# Altium schematic sheet CSMS.SchDoc
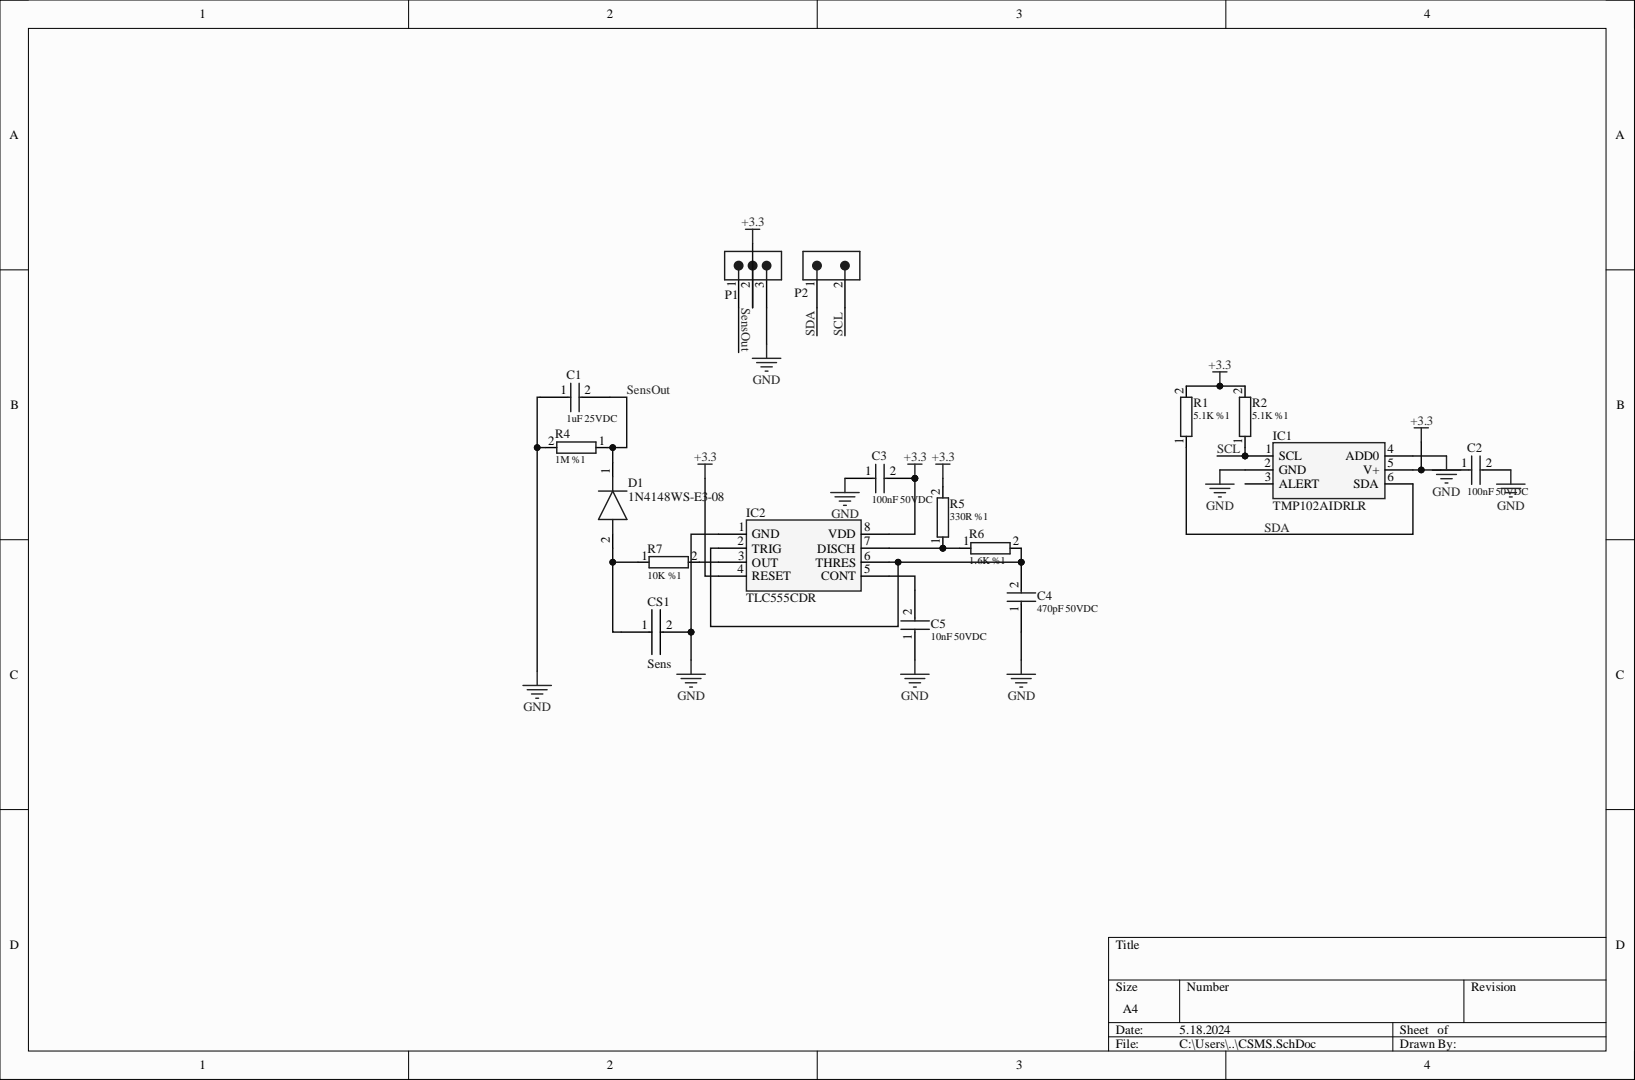
[diagram p0: CSMS.SchDoc]

## Parts
C1  value 1uF 25VDC  [0603 CAP]  (hidden comment: CL10B105KA8NNNC)
C2  value 100nF 50VDC  [0603 CAP]  (hidden comment: CL10B104KB8NNNC)
C3  value 100nF 50VDC  [0603 CAP]  (hidden comment: CL10B104KB8NNNC)
C4  value 470pF 50VDC  [0603 CAP]  (hidden comment: CL10B471KB8NNNC)
C5  value 10nF 50VDC  [0603 CAP]  (hidden comment: CC0603KRX7R9BB103)
CS1  Sens  [Sens]
D1  1N4148WS-E3-08  [SOD2513X110N]
IC1  TMP102AIDRLR  [SOTFL50P160X60-6N]
IC2  TLC555CDR  [SOIC127P600X175-8N]
P1  61000318221  [61000318221]  (value not drawn)
P2  61000218221  [61000218221]  (value not drawn)
R1  value 5.1K %1  [0603 RES]  (hidden comment: 0603WAF5101T5E)
R2  value 5.1K %1  [0603 RES]  (hidden comment: 0603WAF5101T5E)
R4  value 1M %1  [0603 RES]  (hidden comment: 0603SAF1004T5E)
R5  value 330R %1  [0603 RES]  (hidden comment: 0603SAF3300T5E)
R6  value 1.6K %1  [0603 RES]  (hidden comment: 0603SAF1601T5E)
R7  value 10K %1  [0603 RES]  (hidden comment: 0603SAF1002T5E)

## Nets
+3.3: C2.1 C3.2 IC1.5(V+) IC2.4(RESET) IC2.8(VDD) P1.2 R1.2 R2.2 R5.2
GND: C1.1 C2.2 C3.1 C4.1 C5.1 CS1.2 IC1.2(GND) IC1.4(ADD0) IC2.1(GND) P1.3 R4.2
SCL: IC1.1(SCL) P2.2 R2.1
SDA: IC1.6(SDA) P2.1 R1.1
SensOut: C1.2 D1.1 P1.1 R4.1
NetC4_2: C4.2 IC2.2(TRIG) IC2.6(THRES) R6.2
NetC5_2: C5.2 IC2.5(CONT)
NetCS1_1: CS1.1 D1.2 R7.1
NetR5_1: IC2.7(DISCH) R5.1 R6.1
NetR7_2: IC2.3(OUT) R7.2

Not connected: IC1 3(ALERT)

## Library data of the parts
C1 = CL10B105KA8NNNC: 1uF 25VDC ±10% X7R MLCC 0603; Component Kind: Standard; Ozdisan Price/Stock: https://ozdisan.com/Product/Detail/522144/CL10A106MO8NQNC; Supplier 1: Ozdisan; Supplier 2: Digi-Key; Supplier Part Number 1: CL10B105KA8NNNC; Supplier Part Number 2: 1276-1184-1-ND; Library Name: CSMS.SchLib
C2 C3 = CL10B104KB8NNNC: 100nF 50VDC ±10% X7R MLCC 0603; Component Kind: Standard; Ozdisan Price/Stock: https://ozdisan.com/Product/Detail/522144/CL10A106MO8NQNC; Supplier 1: Digi-Key; Supplier Part Number 1: 1276-1000-1-ND; Library Name: CSMS.SchLib
C4 = CL10B471KB8NNNC: 470pF 50VDC ±10% X7R MLCC 0603; Component Kind: Standard; Ozdisan Price/Stock: https://ozdisan.com/Product/Detail/522144/CL10A106MO8NQNC; Supplier 1: Mouser; Supplier Part Number 1: 187-CL10B471KB8NNNC; Library Name: CSMS.SchLib
C5 = CC0603KRX7R9BB103: 10nF, 50 V, ± 10%, X7R, 0603, Multilayer Ceramic Capacitor,; Component Kind: Standard; Ozdisan Price/Stock: https://ozdisan.com/Product/Detail/522144/CL10A106MO8NQNC; Supplier 1: Mouser; Supplier Part Number 1: 603-CC603KRX7R9BB103; Library Name: CSMS.SchLib
CS1 = Sens: Pcb Sens; Component Kind: Standard; Library Name: CSMS.SchLib
D1 = 1N4148WS-E3-08: Diode; Manufacturer_Name: Vishay; Arrow Part Number: 1N4148WS-E3-08; Arrow Price/Stock: https://www.arrow.com/en/products/1n4148ws-e3-08/vishay?region=europe; Component Kind: Standard; Datasheet Link: https://datasheet.datasheetarchive.com/originals/distributors/Datasheets-DGA23/1390442.pdf; Height: 1.15mm; Manufacturer_Part_Number: 1N4148WS-E3-08; Mouser Part Number: 78-1N4148WS-E3-08; Mouser Price/Stock: https://www.mouser.co.uk/ProductDetail/Vishay-Semiconductors/1N4148WS-E3-08?qs=bTzheeSci6R8fv1OBRENhQ%3D%3D; Supplier 1: Mouser; Supplier Part Number 1: 78-1N4148WS-E3-08; Library Name: CSMS.SchLib
IC1 = TMP102AIDRLR: Integrated Circuit; Manufacturer_Name: Texas Instruments; Arrow Part Number: TMP102AIDRLR; Arrow Price/Stock: https://www.arrow.com/en/products/tmp102aidrlr/texas-instruments?region=nac; Component Kind: Standard; Datasheet Link: http://www.ti.com/lit/gpn/tmp102; Height: 0.6mm; Manufacturer_Part_Number: TMP102AIDRLR; Mouser Part Number: 595-TMP102AIDRLR; Mouser Price/Stock: https://www.mouser.co.uk/ProductDetail/Texas-Instruments/TMP102AIDRLR?qs=SUwrw6jWmlKK8eMrKfK4LA%3D%3D; Library Name: CSMS.SchLib
IC2 = TLC555CDR: 555 Astable 2.1-MHz, 250-µA, Low-Power Timer 8-SOIC 0 to 70; Manufacturer_Name: Texas Instruments; Arrow Part Number: TLC555CDR; Arrow Price/Stock: https://www.arrow.com/en/products/tlc555cdr/texas-instruments?region=nac; Component Kind: Standard; Datasheet Link: https://www.ti.com/lit/ds/symlink/tlc555.pdf?ts=1599218356509&ref_url=https%253A%252F%252Fwww.ti.com%252Fstore%252Fti%252Fen%252Fp%252Fproduct%252F%253Fp%253DTLC555CDR%2526keyMatch%253DTLC555CDR%2526tisearch%253DSearch-EN-everything%2526usecase%253DOPN; Height: 1.75mm; Manufacturer_Part_Number: TLC555CDR; Mouser Part Number: 595-TLC555CDR; Mouser Price/Stock: https://www.mouser.co.uk/ProductDetail/Texas-Instruments/TLC555CDR?qs=gb35HGp1gQImgWgRW%252BGYaw%3D%3D; Supplier 1: Mouser; Supplier Part Number 1: 595-TLC555CDR; Library Name: CSMS.SchLib
P1 = 61000318221: WR-PHD Pin Header, THT, Angled, pitch 2.54mm, 1 Row, 3P; Manufacturer: Wurth Elektronik; Manufacturer Part Number: 61300311021; Contact Resistance: 20mΩ; Rated Current: 3A; Withstanding Voltage: 500V; Working Voltage: 250V; Category: Board-to-Board Connectors; Component Kind: Standard; ComponentLink1Description: Website Link; ComponentLink1URL: https://www.we-online.com/redexpert/article/61300311021?ad; ComponentLink2Description: Datasheet Link; ComponentLink2URL: https://www.we-online.com/redexpert/spec/61300311021?ad; Gender: Pin Header; Match Code: WR-PHD; Mount: Through Hole; Number of Pins: 3; Number of Rows: 1; Operating Temperature Max: 105°C; Operating Temperature Min: -40°C; Orientation: Angled; Packaging: Bag; Pitch: 2.54mm; Supplier 1: Digi-Key; Supplier Part Number 1: 732-5336-ND; Library Name: CSMS.SchLib
P2 = 61000218221: WR-PHD Pin Header, THT, Angled, pitch 2.54mm, 1 Row, 3P; Manufacturer: Wurth Elektronik; Manufacturer Part Number: 61300311021; Contact Resistance: 20mΩ; Rated Current: 3A; Withstanding Voltage: 500V; Working Voltage: 250V; Category: Board-to-Board Connectors; Component Kind: Standard; ComponentLink1Description: Website Link; ComponentLink1URL: https://www.we-online.com/redexpert/article/61300311021?ad; ComponentLink2Description: Datasheet Link; ComponentLink2URL: https://www.we-online.com/redexpert/spec/61300311021?ad; Gender: Pin Header; Match Code: WR-PHD; Mount: Through Hole; Number of Pins: 3; Number of Rows: 1; Operating Temperature Max: 105°C; Operating Temperature Min: -40°C; Orientation: Angled; Packaging: Bag; Pitch: 2.54mm; Supplier 1: Digi-Key; Supplier Part Number 1: 732-5336-ND; Library Name: CSMS.SchLib
R1 = 0603WAF5101T5E: 5.1 K Ohms 1% 1/10W-S 100PPM RES 0603; Value: 5.1K %1; Component Kind: Standard; JLCPCB Part #: C23186; Ozdisan Price/Stock: https://ozdisan.com/Product/Detail/522144/CL10A106MO8NQNC; Supplier 1: Avnet; Supplier Part Number 1: 39P9958; Library Name: CSMS.SchLib
R2 = 0603WAF5101T5E: 5.1 K Ohms 1% 1/10W-S 100PPM RES 0603; Component Kind: Standard; JLCPCB Part #: C23186; Ozdisan Price/Stock: https://ozdisan.com/Product/Detail/522144/CL10A106MO8NQNC; Supplier 1: Avnet; Supplier Part Number 1: 39P9958; Library Name: CSMS.SchLib
R4 = 0603SAF1004T5E: 1M Ohms 1% 1/10W-S 100PPM RES 0603; Component Kind: Standard; Ozdisan Price/Stock: https://ozdisan.com/Product/Detail/522144/CL10A106MO8NQNC; Supplier 1: Newark; Supplier Part Number 1: 79M5904; Library Name: CSMS.SchLib
R5 = 0603SAF3300T5E: 330R Ohms 1% 1/10W-S 100PPM RES 0603; Value: 330R %1; Component Kind: Standard; Ozdisan Price/Stock: https://ozdisan.com/Product/Detail/522144/CL10A106MO8NQNC; Supplier 1: Mouser; Supplier Part Number 1: 603-RC0603FR-07330RL; Library Name: CSMS.SchLib
R6 = 0603SAF1601T5E: 1.6K Ohms 1% 1/10W-S 100PPM RES 0603; Component Kind: Standard; Ozdisan Price/Stock: https://ozdisan.com/Product/Detail/522144/CL10A106MO8NQNC; Supplier 1: Avnet; Supplier Part Number 1: 39P9958; Library Name: CSMS.SchLib
R7 = 0603SAF1002T5E: 10K Ohms 1% 1/10W-S 100PPM RES 0603; Component Kind: Standard; Ozdisan Price/Stock: https://ozdisan.com/Product/Detail/522144/CL10A106MO8NQNC; Supplier 1: Farnell; Supplier Part Number 1: 1632392; Library Name: CSMS.SchLib
